# Altium schematic sheet [PL-CRF-01]nRF53_V4.0.SchDoc
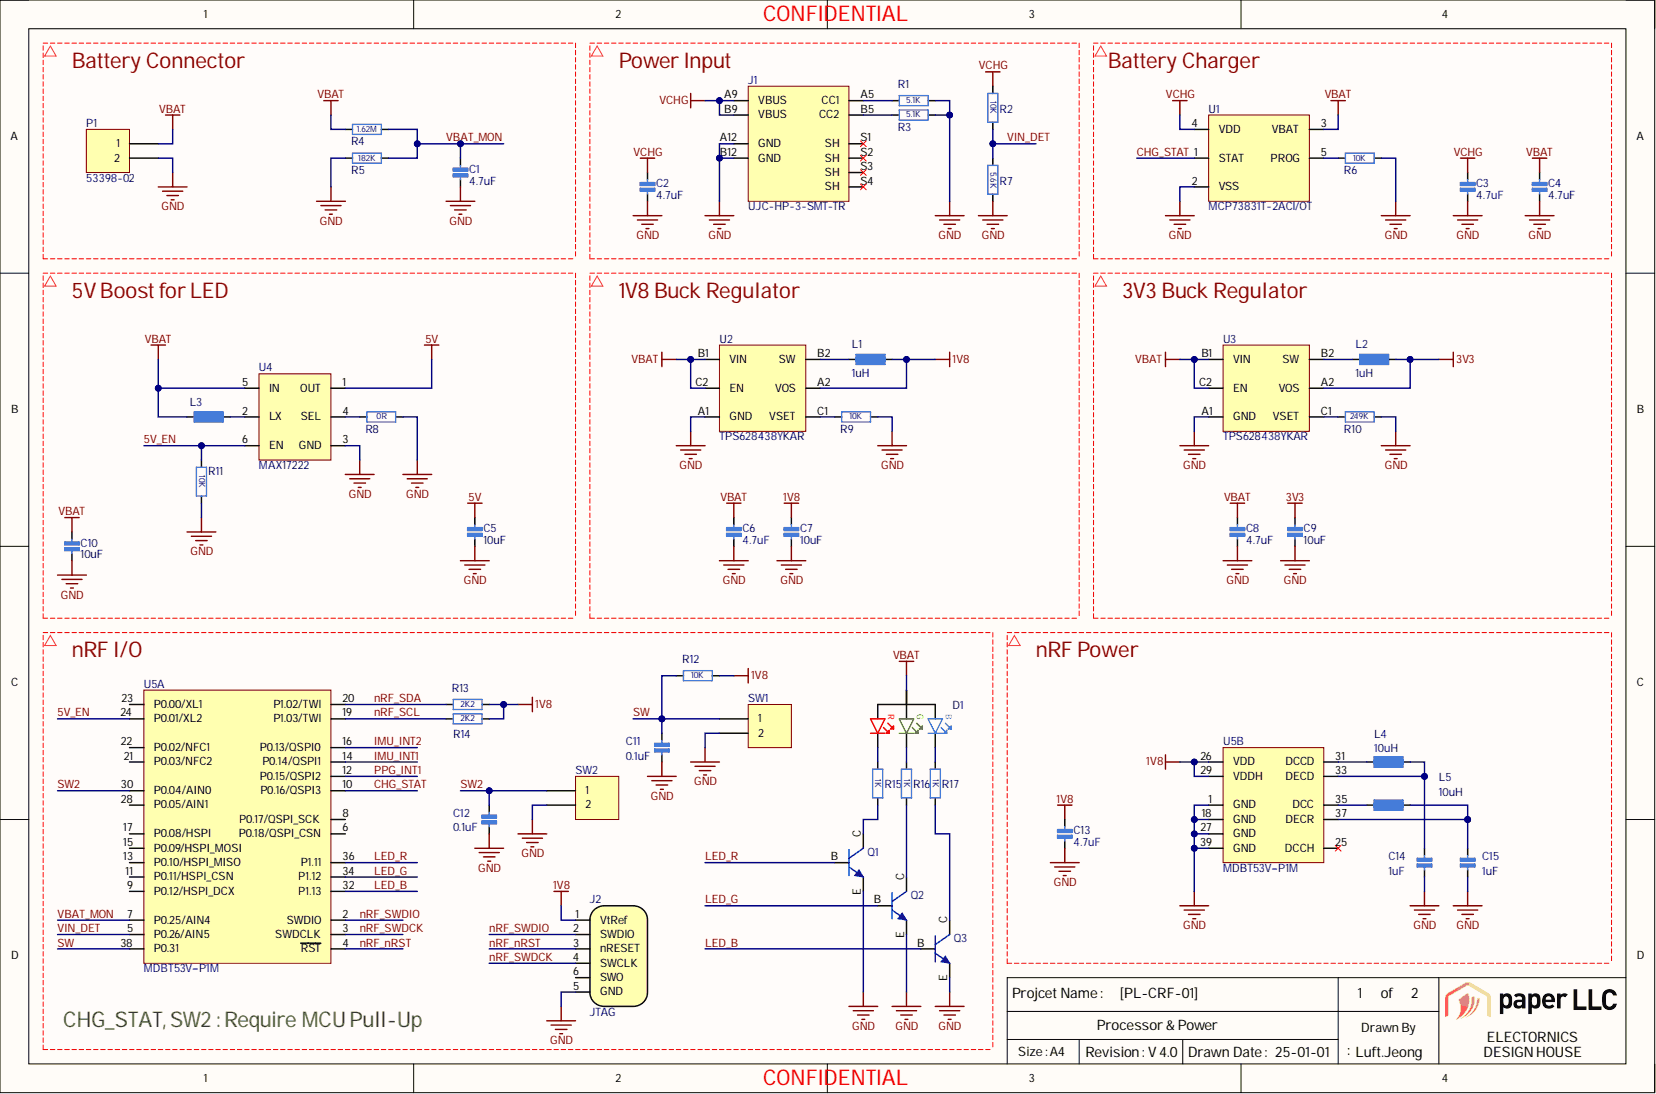
[diagram p0: [PL-CRF-01]nRF53_V4.0.SchDoc]

## Parts
C1  4.7uF  [CAPC1005X55N_2]
C2  4.7uF  [CAPC1005X55N_2]
C3  4.7uF  [CAPC1005X55N_2]
C4  4.7uF  [CAPC1005X55N_2]
C5  10uF  [CAPC1005X55N_2]
C6  4.7uF  [CAPC1005X55N_2]
C7  10uF  [CAPC1005X55N_2]
C8  4.7uF  [CAPC1005X55N_2]
C9  10uF  [CAPC1005X55N_2]
C10  10uF  [CAPC1005X55N_2]
C11  0.1uF  [CAPC1005X55N_2]
C12  0.1uF  [CAPC1005X55N_2]
C13  4.7uF  [CAPC1005X55N_2]
C14  1uF  [CAPC1005X55N_2]
C15  1uF  [CAPC1005X55N_2]
D1  RGB LED  [WL-SFSW_1204_155124M172400]  (value not drawn)
J1  UJC-HP-3-SMT-TR  [CUI_UJC-HP-3-SMT-TR]
J2  JTAG  [EC06_Edge]  (no BOM)
L1  1uH  [DFE201610P]
L2  1uH  [DFE201610P]
L3  74438357022  [XFL4020 SERIES]  (value not drawn)
L4  10uH  [INDC1608N]
L5  10uH  [INDC1608N]
P1  53398-02  [53398-02]
Q1  DTC124EET1G  [SC-75]  (value not drawn)
Q2  DTC124EET1G  [SC-75]  (value not drawn)
Q3  DTC124EET1G  [SC-75]  (value not drawn)
R1  5.1K  [RESC1005X40N_2]
R2  10K  [RESC1005X40N_2]
R3  5.1K  [RESC1005X40N_2]
R4  1.62M  [RESC1005X40N_2]
R5  182K  [RESC1005X40N_2]
R6  10K  [RESC1005X40N_2]
R7  5.6K  [RESC1005X40N_2]
R8  0R  [RESC1005X40N_2]
R9  10K  [RESC1005X40N_2]
R10  249K  [RESC1005X40N_2]
R11  10K  [RESC1005X40N_2]
R12  10K  [RESC1005X40N_2]
R13  2K2  [RESC1005X40N_2]
R14  2K2  [RESC1005X40N_2]
R15  1K  [RESC1005X40N_2]
R16  1K  [RESC1005X40N_2]
R17  1K  [RESC1005X40N_2]
SW1  Header 2  [SKRK]  (value not drawn)
SW2  Header 2  [SKRK]  (value not drawn)
U1  MCP73831T-2ACI/OT  [MICROCHIP SOT-23-5 OT]
U2  TPS628438YKAR  [TPS628438YKAR]
U3  TPS628438YKAR  [TPS628438YKAR]
U4  MAX17222  [FP-L622-1C-MFG]
U5  MDBT53V–P1M  [MDBT53V]  (parts A,B)

## Nets
1V8: C7.1 C13.1 J2.1(VtRef) L1.2 R12.2 R13.2 R14.2 U2.A2(VOS) U5.26(VDD) U5.29(VDDH)
3V3: C9.1 L2.2 U3.A2(VOS)
5V: C5.1 U4.1(OUT)
GND: C1.2 C2.2 C3.2 C4.2 C5.2 C6.2 C7.2 C8.2 C9.2 C10.2 C11.2 C12.2 C13.2 C14.2 C15.2 J1.A12(GND) J1.B12(GND) J2.5(GND) P1.2 Q1.E Q2.E Q3.E R1.2 R3.2 R5.2 R6.2 R7.2 R8.2 R9.2 R10.2 R11.2 SW1.2 SW2.2 U1.2(VSS) U2.A1(GND) U3.A1(GND) U4.3(GND) U5.1(GND) U5.18(GND) U5.27(GND) U5.39(GND)
VBAT: C4.1 C6.1 C8.1 C10.1 D1.1 L3.1 P1.1 R4.2 U1.3(VBAT) U2.B1(VIN) U2.C2(EN) U3.B1(VIN) U3.C2(EN) U4.5(IN)
VCHG: C2.1 C3.1 J1.A9(VBUS) J1.B9(VBUS) R2.1 U1.4(VDD)
5V_EN: R11.1 U4.6(EN) U5.24(P0.01/XL2)
CHG_STAT: U1.1(STAT) U5.10(P0.16/QSPI3)
IMU_INT1: U5.14(P0.14/QSPI1)
IMU_INT2: U5.16(P0.13/QSPI0)
LED_B: Q3.B U5.32(P1.13)
LED_G: Q2.B U5.34(P1.12)
LED_R: Q1.B U5.36(P1.11)
nRF_nRST: J2.3(nRESET) U5.4(RST)
nRF_SCL: R14.1 U5.19(P1.03/TWI)
nRF_SDA: R13.1 U5.20(P1.02/TWI)
nRF_SWDCK: J2.4(SWCLK) U5.3(SWDCLK)
nRF_SWDIO: J2.2(SWDIO) U5.2(SWDIO)
PPG_INT1: U5.12(P0.15/QSPI2)
SW: C11.1 R12.1 SW1.1 U5.38(P0.31)
SW2: C12.1 SW2.1 U5.30(P0.04/AIN0)
VBAT_MON: C1.1 R4.1 R5.1 U5.7(P0.25/AIN4)
VIN_DET: R2.2 R7.1 U5.5(P0.26/AIN5)
NetC14_1: C14.1 L4.2 U5.33(DECD)
NetC15_1: C15.1 L5.2 U5.37(DECR)
NetD1_2: D1.2 R17.1
NetD1_3: D1.3 R16.1
NetD1_4: D1.4 R15.1
NetJ1_A5: J1.A5(CC1) R1.1
NetJ1_B5: J1.B5(CC2) R3.1
NetL1_1: L1.1 U2.B2(SW)
NetL2_1: L2.1 U3.B2(SW)
NetL3_2: L3.2 U4.2(LX)
NetL4_1: L4.1 U5.31(DCCD)
NetL5_1: L5.1 U5.35(DCC)
NetQ1_C: Q1.C R15.2
NetQ2_C: Q2.C R16.2
NetQ3_C: Q3.C R17.2
NetR6_1: R6.1 U1.5(PROG)
NetR8_1: R8.1 U4.4(SEL)
NetR9_1: R9.1 U2.C1(VSET)
NetR10_1: R10.1 U3.C1(VSET)

Not connected: J1 S1(SH) S2(SH) S3(SH) S4(SH); J2 6(SWO); U5 6(P0.18/QSPI_CSN) 8(P0.17/QSPI_SCK) 9(P0.12/HSPI_DCX) 11(P0.11/HSPI_CSN) 13(P0.10/HSPI_MISO) 15(P0.09/HSPI_MOSI) 17(P0.08/HSPI) 21(P0.03/NFC2) 22(P0.02/NFC1) 23(P0.00/XL1) 25(DCCH) 28(P0.05/AIN1)

## Library data of the parts
C1-C15 = CAP_MLCC: Ceramic MLCC CAP; Manufacture: Murata; Dielectric: X5R; Volage Rating: 50V; Component Kind: Standard; Library Name: PAPER_Basic_Lib.SchLib
  C1-C4 C6 C8 C13: Value: 4.7uF
  C5 C7 C9 C10: Value: 10uF
  C11 C12: Value: 0.1uF
  C14 C15: Value: 1uF
D1 = RGB LED_4: RGB LED_COMMON ANODE; Component Kind: Standard; Library Name: Paper.SchLib
J1 = UJC-HP-3-SMT-TR: Type C, 3 A, Right Angle, Surface Mount (SMT), Power-Only USB Receptacle; Availability: Unavailable; Component Kind: Standard; MP: UJC-HP-3-SMT-TR; Package: None; Price: None; Library Name: CRF.SchLib
J2 = TC2030-MCP-NL: Conector par ICD; Library Name: Paper.SchLib
L1 L2 L4 L5 = INDUCTOR: Inductor SMD; Component Kind: Standard; Library Name: PAPER_Basic_Lib.SchLib
  L1 L2: Value: 1uH
  L4 L5: Value: 10uH
L3 = INDUCTOR: Inductor SMD; Full Part Number: LPS3008-472MRC; Value: 2.2uH; Component Kind: Standard; Library Name: PAPER_Basic_Lib.SchLib
P1 SW1 SW2 = Header 2: Header, 2-Pin; Component Kind: Standard; LatestRevisionDate: 17-Jul-2002; LatestRevisionNote: Re-released for DXP Platform.; Library Name: Miscellaneous Connectors.IntLib; Signal Integrity: Connector; Publisher: Altium Limited
Q1-Q3 = NPN TR: NPN PRE-BIASED SMALL SIGNAL SURFACE MOUNT TRANSISTOR; Component Kind: Standard; ComponentLink1Description: Manufacturer Link; ComponentLink1URL: http://www.fairchildsemi.com/; ComponentLink2Description: Datasheet; ComponentLink2URL: http://www.fairchildsemi.com/ds/2N%2F2N3904.pdf; Signal Integrity: 2N3904; Simulation: 2N3904; Library Name: Paper.SchLib
R1-R17 = R_Film_Chip: Chip FIlm Resistor; Full Part Number: CRCW0603; Manufacture: Vishay; Component Kind: Standard; Library Name: PAPER_Basic_Lib.SchLib
  R1 R3: Value: 5.1K
  R2 R6 R9 R11 R12: Value: 10K
  R4: Value: 1.62M
  R5: Value: 182K
  R7: Value: 5.6K
  R8: Value: 0R
  R10: Value: 249K
  R13 R14: Value: 2K2
  R15-R17: Value: 1K
U1 = MCP73831: Miniature Single-Cell, Fully Integrated Li-Ion,  Li-Polymer Charge Management Controllers; Component Kind: Standard; Library Name: Paper.SchLib
U2 U3 = TPS628438YKAR: 1.8V to 5.5V, 600mA, 275nA IQ , Small-Size Step-Down Converters; Component Kind: Standard; Library Name: CRF.SchLib
U4 = MAX17222: 400mV to 5.5V Input, nanoPower Synchronous  Boost Converters with True Shutdown; Component Kind: Standard; Library Name: CRF.SchLib
U5 = MDBT53V–P1M: Bluetooth Low Energy Module; Component Kind: Standard; Library Name: CRF.SchLib
